# Altium schematic sheet 07_Buzzer_UART.SchDoc(07_Buzzer_UART)
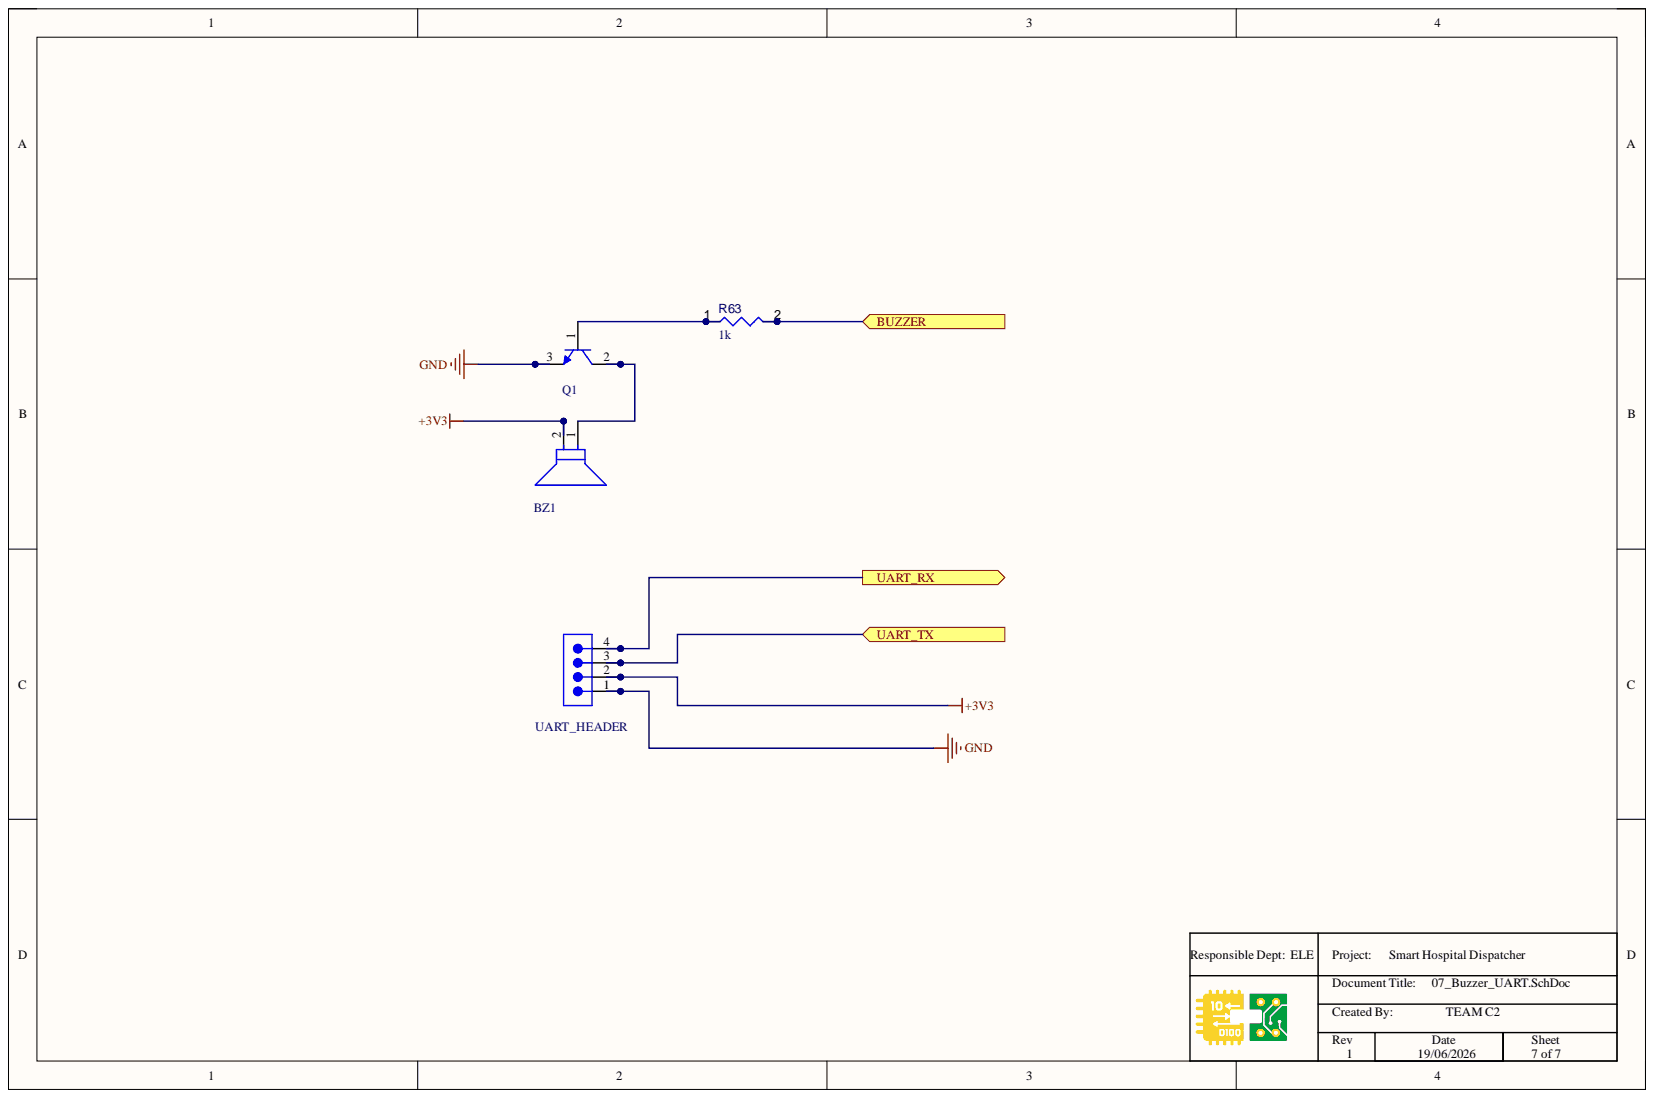
[diagram p0: 07_Buzzer_UART.SchDoc]

## Parts
BZ1  AI-1223-TWT-3V-2-R  [FP-AI-1223-TWT-3V-2-R-MFG]  (value not drawn)
Q1  2N2222A  [STM-TO-18-3]  (value not drawn)
R63  value 1k  [RESC1005X40X25ML10T10]  (hidden comment: Resistor 1k +/-5% 0402 63 mW)
UART_HEADER  691701310004B  [691701310004B]  (value not drawn)

## Ports
BUZZER, UART_RX, UART_TX

## Nets
+3V3: BZ1.2 UART_HEADER.2
GND: Q1.3 UART_HEADER.1
BUZZER: R63.2 ; port BUZZER
UART_RX: UART_HEADER.4 ; port UART_RX
UART_TX: UART_HEADER.3 ; port UART_TX
NetBZ1_1: BZ1.1 Q1.2
NetQ1_1: Q1.1 R63.1

## Library data of the parts
BZ1 = CMP-103888-000003-1: BUZZER MAGNETIC 3V 12MM TH; Frequency: 2.3kHz; Input Type: DC; Max Supply Current: 30mA; Max Supply Voltage (DC): 4V; Min Supply Voltage (DC): 2V; Component Kind: Standard; Contact Plating: Tin; Diameter: 12mm; Height: 7.5mm; Library Name: Altium Content Vault; Max Operating Temperature: 70°C; Min Operating Temperature: -20°C; Mount: Through Hole; Nominal Supply Voltage (DC): 3V; Operating Supply Current: 30mA; Packaging: Tray; Sensing Method: Magnetic; Series: AI; Termination: PC Pins; Voltage Rating: 3V
Q1 = CMP-2000-05381-1: High Speed Switch, 0.6 A IC, -65 to 175 degC, 3-Pin TO-18, RoHS, Bulk; Manufacturer: STMicroelectronics; Collector Emitter Voltage V(br)ceo: 40V; DC Collector Current: 600mA; DC Current Gain hFE: 300hFE; No. of Pins: 3Pins; Power Dissipation Pd: 500mW; Transistor Polarity: NPN; Transition Frequency ft: 300MHz; Automotive Qualification Standard: -; Component Kind: Standard; Datasheet URL: https://datasheet.ciiva.com/25917/cd00003223-25917094.pdf; Datasheet Version: Feb-03; Library Name: Altium Content Vault; Manufacturer URL: http://www.st.com/; Operating Temperature Max: 175degC; Package Description: 3-Pin Through Hole Device, Dia 5.8 mm, Pitch 1.27 mm; Package Reference: TO-18-3; Product Range: -; Transistor Case Style: TO-18
R63 = CMP-009-00013-5: Chip Resistor, 1 KOhm, +/- 5%, 0.063 W, -55 to 155 degC, 0402 (1005 Metric); Power: 63mW; Case/Package: 0402; Component Kind: Standard; Max Operating Temperature: 155°C; Min Operating Temperature: -55°C; Mounting Technology: SM; Pins: 2; RoHS Compliant: True; Tolerance: 5%; Library Name: BERTRAND MUNGU CHO
UART_HEADER = CMP-1502-01983-3: Terminal Block, 3.81MM Pitch, Vertical Pcb Header, Thr Type, 4 Pins; Current Rating: 10A; Gender: Male; Number of Contacts: 4; Voltage Rating: 300V; Component Kind: Standard; Connector Type: Terminal Block; Contact Material: Brass; Contact Plating: Tin; Lead Pitch: 3.81mm; Length: 16.63mm; Library Name: Altium Content Vault; Max Operating Temperature: 105°C; Min Operating Temperature: -40°C; Mount: Through Hole; Number of Pins: 4; Packaging: Box; Pitch: 3.81mm; REACH SVHC: Unknown; RoHS: Compliant
